# Altium schematic sheet XYAmpRev2b.SchDoc (continued)

## Library data of the parts
C70 C72 = Cap: CAP CER 10PF 50V C0G 0805; Manufacturer: KEMET; Manufacturer Part Number: C0805C100K5GACTU; Dielectric Material: Ceramic; Dissipation Factor: 0.1%; Tolerance: 10%; Capacitance: 10pF; Case Code (Imperial): 0805; Case Code (Metric): 2012; Case/Package: 0805; Component Kind: Standard; Construction: Flat; Contact Plating: Tin,Matte; Depth: 1.25mm; Height: 0.78mm; Lead Free: Lead Free; Lead Pitch: 0.75mm; Length: 2mm; Library Name: Miscellaneous Devices.IntLib; Max Operating Temperature: 125°C; Min Operating Temperature: -55°C; Mount: Surface Mount; Package Quantity: 4000; Packaging: Tape and Reel; Radiation Hardening: No; REACH SVHC: No SVHC; RoHS Compliant: Yes; Series: C; Signal Integrity: Cap; Simulation: CAP; Supplier: Digi-Key; Supplier Part Number: 399-7975-6-ND; Termination: SMD/SMT; Thickness: 0.035inch; Value: 10pF; Voltage Rating: 50V; Voltage Rating (DC): 50V; Width: 0.049inch
C71 C73 = Cap: CAP CER 100PF 50V COG 0805; Manufacturer: KEMET; Manufacturer Part Number: C0805C101J5GACTU; Dielectric Material: Ceramic; Dissipation Factor: 0.1%; Temperature Coefficient: 30ppm/°C; Tolerance: 5%; Capacitance: 100pF; Case Code (Imperial): 0805; Case Code (Metric): 2012; Case/Package: 0805; Component Kind: Standard; Construction: Flat; Contact Material: Tin; Contact Plating: Tin,Matte; Depth: 1.25mm; Height: 0.78mm; Lead Free: Lead Free; Lead Pitch: 0.75mm; Length: 2mm; Library Name: Miscellaneous Devices.IntLib; Max Operating Temperature: 125°C; Min Operating Temperature: -55°C; Mount: Surface Mount,PCB; Number of Pins: 2; Package Quantity: 4000; Packaging: Tape and Reel; Radiation Hardening: No; REACH SVHC: No SVHC; RoHS Compliant: Yes; Series: C; Signal Integrity: Cap; Simulation: CAP; Supplier: Digi-Key; Supplier Part Number: 399-1122-6-ND; Termination: SMD/SMT; Thickness: 0.035inch; Value: 100pF; Voltage: 50V; Voltage Rating: 50V; Voltage Rating (DC): 50V; Width: 1.2mm
C74 = Cap: CAP FILM 0.1UF 20% 63VDC PEN 1210; Manufacturer: KEMET; Manufacturer Part Number: LDEDB3100KA0N00; Capacitance: 100nF; Tolerance: 10%; Voltage: 63V; Voltage Rating (AC): 40V; Case Code (Imperial): 1210; Case Code (Metric): 3225; Case/Package: 1210; Component Kind: Standard; Depth: 2.5mm; Features: High Temperature; Height: 2.1mm; Height - Seated (Max): 0.083inch; Length: 3.3mm; Library Name: Miscellaneous Devices.IntLib; Max Operating Temperature: 125°C; Min Operating Temperature: -55°C; Mount: Surface Mount; Packaging: Cut Tape; REACH SVHC: No SVHC; Signal Integrity: Cap; Simulation: CAP; Supplier: Digi-Key; Supplier Part Number: 399-12863-1-ND; Termination: Solder; Voltage Rating: 63V; Voltage Rating (DC): 63V; Width: 0.098inch
C75 C77 C81 C83 = Cap: CAP CER 10NF 100V X7R 0805; Manufacturer: Samsung; Manufacturer Part Number: CL21B103KCANNNC; Dielectric Material: Ceramic; Dissipation Factor: 2.5%; Tolerance: 10%; Capacitance: 10nF; Case Code (Imperial): 0805; Case Code (Metric): 2012; Case/Package: 0805; Component Kind: Standard; Construction: Flat; Contact Plating: Tin; Depth: 1.25mm; Height: 0.65mm; Length: 2mm; Library Name: Miscellaneous Devices.IntLib; Material: Ceramic; Max Operating Temperature: 125°C; Min Operating Temperature: -55°C; Mount: Surface Mount; Number of Pins: 2; Package Quantity: 1; Packaging: Tape and Reel; Radiation Hardening: No; REACH SVHC: No SVHC; RoHS Compliant: Yes; Series: CL; Signal Integrity: Cap; Simulation: CAP; Supplier: Digi-Key; Supplier Part Number: 1276-1249-6-ND; Termination: SMD/SMT; Thickness: 0.03inch; Value: 10nF; Voltage Rating: 100V; Voltage Rating (DC): 100V; Width: 0.049inch
C76 C78 C80 C82 = Cap: CAP CER 1UF 50V X7R 0805; Manufacturer: Samsung; Manufacturer Part Number: CL21B105KBFNNNE; Dielectric Material: Ceramic; Tolerance: 10%; Capacitance: 1uF; Case Code (Imperial): 0805; Case Code (Metric): 2012; Case/Package: 0805; Component Kind: Standard; Construction: Flat; Depth: 1.25mm; Height: 1.25mm; Length: 2mm; Library Name: Miscellaneous Devices.IntLib; Max Operating Temperature: 125°C; Min Operating Temperature: -55°C; Mount: Surface Mount; Number of Pins: 2; Package Quantity: 1; Packaging: Tape and Reel; Radiation Hardening: No; REACH SVHC: No SVHC; RoHS Compliant: Yes; Series: CL; Signal Integrity: Cap; Simulation: CAP; Supplier: Digi-Key; Supplier Part Number: 1276-1029-6-ND; Termination: SMD/SMT; Thickness: 0.053inch; Value: 1uF; Voltage Rating: 50V; Voltage Rating (DC): 50V; Width: 0.049inch
C79 = Cap Pol1: CAP ALUM 100UF 20% 35V; Manufacturer: Rubycon; Manufacturer Part Number: 35YXJ100M6.3X11; Impedance: 220mR; Lead Diameter: 0.5mm; Leakage Current: 3uA; Life (Hours): 7000Hour; Polarity: Polar; Ripple Current: 400mA; Capacitance: 100uF; Case/Package: Radial; Component Kind: Standard; Diameter: 6.3mm; Height: 11mm; Height - Seated (Max): 0.492inch; Lead Pitch: 2.5mm; Library Name: Miscellaneous Devices.IntLib; Max Operating Temperature: 105°C; Min Operating Temperature: -40°C; Mount: Through Hole; Package Quantity: 3000; Packaging: Bulk; Radiation Hardening: No; Signal Integrity: Cap Pol; Simulation: CAP; Supplier: Digi-Key; Supplier Part Number: 1189-2260-ND; Termination: Radial; Tolerance: 20%; Value: 100uF; Voltage Rating: 35V
C84 = Cap Pol1: CAP ALUM 100uF 20% 25V; Manufacturer: Rubycon; Manufacturer Part Number: 25YXJ100M5X11; Impedance: 400mR; Lead Diameter: 0.5mm; Leakage Current: 3uA; Life (Hours): 5000Hour; Polarity: Polar; Ripple Current: 250mA; Capacitance: 100uF; Case/Package: Radial; Category: Aluminum Electrolytic Capacitors; Component Kind: Standard; ComponentLink1Description: https://datasheet.ciiva.com/11777/0900766b810307a1-11777001.pdf?src-supplier=RSComponents; ComponentLink1URL: https://datasheet.ciiva.com/11777/0900766b810307a1-11777001.pdf?src-supplier=RSComponents; ComponentLink2Description: https://datasheet.ciiva.com/41806/e-yxj-41806041.pdf?src-supplier=Digi-Key; ComponentLink2URL: https://datasheet.ciiva.com/41806/e-yxj-41806041.pdf?src-supplier=Digi-Key; ComponentLink3Description: https://datasheet.ciiva.com/11690/e-yxj-11690904.pdf?src-supplier=Avnet; ComponentLink3URL: https://datasheet.ciiva.com/11690/e-yxj-11690904.pdf?src-supplier=Avnet; Construction: RADIAL; Diameter: 5mm; Height: 11mm; Height - Seated (Max): 0.492inch; Lead Pitch: 2mm; Library Name: Miscellaneous Devices.IntLib; Max Operating Temperature: 105°C; Min Operating Temperature: -40°C; Mount: Through Hole; Package Quantity: 3000; Packaging: Bulk; Pricing 1: 1=0.25000, 10=0.17200, 50=0.10360, 100=0.08740, 500=0.05980, 1000=0.05290, 2500=0.05060, 5000=0.04600 (USD); Radiation Hardening: No; RoHS: Yes; RoHS Compliant: Yes; Series: YXJ; Signal Integrity: Cap Pol; Simulation: CAP; Stock 1: 7789; Supplier: Digi-Key; Supplier Part Number 1: 1189-2237-ND; Termination: Radial; Tolerance: 20%; Value: 100uF; Voltage Rating: 25V
C85 C86 = Cap: CAP CER 100PF 250V COG 0805; Manufacturer: Yageo; Manufacturer Part Number: AC0805JRNPOYBN101; Category: Ceramic Capacitors; Component Kind: Standard; ComponentLink1Description: http://www.yageo.com/NewPortal/_en/search/productDocs.jsp?YageoPartNumber=AC0805JRNPOYBN101&src-supplier=Digi-Key; ComponentLink1URL: http://www.yageo.com/NewPortal/_en/search/productDocs.jsp?YageoPartNumber=AC0805JRNPOYBN101&src-supplier=Digi-Key; Library Name: Miscellaneous Devices.IntLib; Pricing 1: 1=0.22000, 10=0.14800, 50=0.08920, 100=0.07520, 500=0.05148, 1000=0.04554 (USD); RoHS: Yes; RoHS Compliant: Yes; Signal Integrity: Cap; Simulation: CAP; Supplier: Digi-Key; Supplier Part Number: 311-3140-1-ND
C87 = Cap: CAP CER 0.1UF 50V X7R 0805; Manufacturer: KEMET; Manufacturer Part Number: C0805C104M5RACTU; Capacitance: 100nF; Dielectric Material: Ceramic; Dissipation Factor: 2.5%; Tolerance: 20%; Case Code (Imperial): 0805; Case Code (Metric): 2012; Case/Package: 0805; Component Kind: Standard; Construction: Flat; Depth: 1.25mm; Height: 0.9mm; Length: 2mm; Library Name: Miscellaneous Devices.IntLib; Max Operating Temperature: 125°C; Min Operating Temperature: -55°C; Mount: Surface Mount,PCB; Number of Pins: 2; Package Quantity: 1; Packaging: Tape and Reel; Radiation Hardening: No; REACH SVHC: No SVHC; Series: C; Signal Integrity: Cap; Simulation: CAP; Supplier: Digi-Key; Supplier Part Number: 399-1169-2-ND; Termination: SMD/SMT; Thickness: 0.035inch; Value: 0.1uF; Voltage Rating: 50V; Voltage Rating (DC): 50V; Weight: 0.000194oz; Width: 1.2mm
D70 = Diode 1N4148: Diode; 500 mA (Surge); 1.0 V (Max.); 400 mW; 450 degC/W; 4 pF (Max.); 4 nS (Max; Manufacturer: Vishay; Manufacturer Part Number: 1N4148W-E3-18; Average Rectified Current: 150mA; Element Configuration: Single; Forward Voltage: 1.2V; Max Power Dissipation: 350mW; Max Repetitive Reverse Voltage (Vrrm): 100V; Max Reverse Current: 100uA; Max Reverse Leakage Current: 5uA; Max Reverse Voltage (DC): 75V; Max Surge Current: 2A; Recovery Time: 4ns; Category: Rectifier Diodes; Component Kind: Standard; ComponentLink1Description: Manufacturer Link; ComponentLink1URL: http://www.fairchildsemi.com/; ComponentLink2Description: Datasheet; ComponentLink2URL: http://www.fairchildsemi.com/ds/1N%2F1N4148.pdf; ComponentLink3Description: https://datasheet.ciiva.com/29885/1n4148w-29885941.pdf?src-supplier=Avnet; ComponentLink3URL: https://datasheet.ciiva.com/29885/1n4148w-29885941.pdf?src-supplier=Avnet; ComponentLink4Description: https://datasheet.ciiva.com/29593/1n4148w-29593111.pdf?src-supplier=Digi-Key; ComponentLink4URL: https://datasheet.ciiva.com/29593/1n4148w-29593111.pdf?src-supplier=Digi-Key; ComponentLink5Description: https://datasheet.ciiva.com/29593/1n4148w-29593112.pdf?src-supplier=Digi-Key; ComponentLink5URL: https://datasheet.ciiva.com/29593/1n4148w-29593112.pdf?src-supplier=Digi-Key; ComponentLink6Description: https://datasheet.ciiva.com/29593/1n4148w-29593113.pdf?src-supplier=Digi-Key; ComponentLink6URL: https://datasheet.ciiva.com/29593/1n4148w-29593113.pdf?src-supplier=Digi-Key; Contact Plating: Tin; Forward Current: 150mA; Height: 1.25mm; Lead Free: Lead Free; Length: 2.85mm; Library Name: Miscellaneous Devices.IntLib; Max Operating Temperature: 150°C; Min Operating Temperature: -55°C; Mount: Surface Mount; Number of Pins: 2; Package Quantity: 10000; Packaging: Tape and Reel; Peak Non-Repetitive Surge Current: 2A; Power Dissipation: 350mW; Pricing 1: 1=0.25000, 10=0.20100, 100=0.10660, 500=0.07012, 1000=0.04768, 2000=0.04301, 5000=0.03740 (USD); Radiation Hardening: No; Reverse Recovery Time: 4ns; RoHS: No; Signal Integrity: 1N4148; Simulation: 1N4148; Stock 1: 103899; Supplier: Digi-Key; Supplier Part Number: 1N4148W-E3-18CT-ND; Value: 1N4148; Weight: 0.000363oz; Width: 1.7mm
D73 = Diode 1N4002: Diode Switching 400V 1A 2-Pin DO-214AC T/R; Manufacturer: ON Semiconductor / Fairchild; Capacitance: 12pF; Element Configuration: Single; Forward Voltage: 1.1V; Max Forward Surge Current (Ifsm): 30A; Max Surge Current: 40A; Peak Reverse Current: 1uA; Polarity: Standard; Power Dissipation: 1.4W; Recovery Time: 1800ns; Reverse Recovery Time: 1.8us; Average Rectified Current: 1A; Case/Package: SMA; Component Kind: Standard; ComponentLink1Description: Manufacturer Link; ComponentLink1URL: http://www.fairchildsemi.com/; ComponentLink2Description: Datasheet; ComponentLink2URL: http://www.fairchildsemi.com/ds/1N%2F1N4001.pdf; Contact Plating: Tin,Matte; Current Rating: 1A; Forward Current: 1A; Height: 2.2mm; Lead Free: Lead Free; Length: 4.75mm; Library Name: Miscellaneous Devices.IntLib; Manufacturer Part Number: S1G; Max Operating Temperature: 150°C; Max Repetitive Reverse Voltage (Vrrm): 400V; Max Reverse Voltage (DC): 400V; Min Operating Temperature: -55°C; Mount: Surface Mount; Number of Pins: 2; Output Current: 1A; Package Quantity: 1; Packaging: Tape and Reel; Peak Non-Repetitive Surge Current: 30A; Radiation Hardening: No; REACH SVHC: No SVHC; Reverse Voltage: 400V; Signal Integrity: 1N4002; Simulation: 1N4002; Supplier: Digi-Key; Supplier Part Number: S1GFSCT-ND; Value: S1G; Voltage Rating (DC): 400V; Weight: 0.106g; Width: 2.95mm
J70 = 2-Pin: 5.08mm 2pin; Manufacturer: CUI Devices; Manufacturer Part Number: TB004-508-02BE; Category: Terminal Blocks; Component Kind: Standard; ComponentLink1Description: https://datasheet.ciiva.com/709/eng-cd-640456-w1-709680.pdf?src-supplier=Digi-Key; ComponentLink1URL: https://datasheet.ciiva.com/709/eng-cd-640456-w1-709680.pdf?src-supplier=Digi-Key; ComponentLink2Description: https://datasheet.ciiva.com/3118/eng-ds-82056-mta-0608-3118497.pdf?src-supplier=Avnet; ComponentLink2URL: https://datasheet.ciiva.com/3118/eng-ds-82056-mta-0608-3118497.pdf?src-supplier=Avnet; ComponentLink3Description: https://datasheet.ciiva.com/16401/eng-ds-82056-mta-0608-16401289.pdf?src-supplier=Future+Electronics; ComponentLink3URL: https://datasheet.ciiva.com/16401/eng-ds-82056-mta-0608-16401289.pdf?src-supplier=Future+Electronics; ComponentLink4Description: https://datasheet.ciiva.com/25617/0900766b80027008-25617244.pdf?src-supplier=RSComponents; ComponentLink4URL: https://datasheet.ciiva.com/25617/0900766b80027008-25617244.pdf?src-supplier=RSComponents; ComponentLink5Description: https://datasheet.ciiva.com/14156/04384301-14156820.pdf?src-supplier=Distrelec; ComponentLink5URL: https://datasheet.ciiva.com/14156/04384301-14156820.pdf?src-supplier=Distrelec; ComponentLink6Description: https://datasheet.ciiva.com/11746/0900766b80eb7fdd-11746365.pdf?src-supplier=RSComponents; ComponentLink6URL: https://datasheet.ciiva.com/11746/0900766b80eb7fdd-11746365.pdf?src-supplier=RSComponents; ComponentLink7Description: https://datasheet.ciiva.com/7128/1866390-7128742.pdf?src-supplier=Newark; ComponentLink7URL: https://datasheet.ciiva.com/7128/1866390-7128742.pdf?src-supplier=Newark; ComponentLink8Description: https://datasheet.ciiva.com/16505/eng-ds-82056-mta-0608-16505419.pdf?src-supplier=Avnet+Asia; ComponentLink8URL: https://datasheet.ciiva.com/16505/eng-ds-82056-mta-0608-16505419.pdf?src-supplier=Avnet+Asia; ComponentLink9Description: https://datasheet.ciiva.com/16047/1984976-16047357.pdf?src-supplier=Onlinecomponents.com; ComponentLink9URL: https://datasheet.ciiva.com/16047/1984976-16047357.pdf?src-supplier=Onlinecomponents.com; ComponentLink10Description: https://datasheet.ciiva.com/11758/0900766b811d406d-11758596.pdf?src-supplier=RSComponents; ComponentLink10URL: https://datasheet.ciiva.com/11758/0900766b811d406d-11758596.pdf?src-supplier=RSComponents; ComponentLink11Description: https://datasheet.ciiva.com/26840/getdatasheetpartid-743835-26840596.pdf?src-supplier=Verical; ComponentLink11URL: https://datasheet.ciiva.com/26840/getdatasheetpartid-743835-26840596.pdf?src-supplier=Verical; ComponentLink12Description: https://datasheet.ciiva.com/6752/combiconspring-cagepcbterm-blocks-6752927.pdf?src-supplier=Digi-Key; ComponentLink12URL: https://datasheet.ciiva.com/6752/combiconspring-cagepcbterm-blocks-6752927.pdf?src-supplier=Digi-Key; Library Name: MY_CONNECTORS.SchLib; Pricing 1: 1=0.80000, 10=0.49800, 25=0.42600, 50=0.38300, 100=0.37510, 250=0.31920, 500=0.30324, 1000=0.27132, 5000=0.21280 (USD); RoHS: Yes; Stock 1: 216; Supplier: Digi-Key; Supplier Part Number: 102-6179-ND
J71 J72 = 2-Pin: Conn PC Terminal Block 2 POS 3.5mm Solder Thru-Hole 17.5A; Manufacturer: Phoenix Contact; Approvals: UL,EAC; Current: 10A; Gender: Male; Max Supply Voltage: 160V; Number of Circuits: 2; Number of Levels: 1; Value: 1988956; Voltage: 300V; Wire Gauge (Max): 26AWG; Wire Gauge (Min): 16AWG; Category: Terminal Blocks; Color: Green; Component Kind: Standard; ComponentLink1Description: https://datasheet.ciiva.com/709/eng-cd-640456-w1-709680.pdf?src-supplier=Digi-Key; ComponentLink1URL: https://datasheet.ciiva.com/709/eng-cd-640456-w1-709680.pdf?src-supplier=Digi-Key; ComponentLink2Description: https://datasheet.ciiva.com/3118/eng-ds-82056-mta-0608-3118497.pdf?src-supplier=Avnet; ComponentLink2URL: https://datasheet.ciiva.com/3118/eng-ds-82056-mta-0608-3118497.pdf?src-supplier=Avnet; ComponentLink3Description: https://datasheet.ciiva.com/16401/eng-ds-82056-mta-0608-16401289.pdf?src-supplier=Future+Electronics; ComponentLink3URL: https://datasheet.ciiva.com/16401/eng-ds-82056-mta-0608-16401289.pdf?src-supplier=Future+Electronics; ComponentLink4Description: https://datasheet.ciiva.com/25617/0900766b80027008-25617244.pdf?src-supplier=RSComponents; ComponentLink4URL: https://datasheet.ciiva.com/25617/0900766b80027008-25617244.pdf?src-supplier=RSComponents; ComponentLink5Description: https://datasheet.ciiva.com/14156/04384301-14156820.pdf?src-supplier=Distrelec; ComponentLink5URL: https://datasheet.ciiva.com/14156/04384301-14156820.pdf?src-supplier=Distrelec; ComponentLink6Description: https://datasheet.ciiva.com/11746/0900766b80eb7fdd-11746365.pdf?src-supplier=RSComponents; ComponentLink6URL: https://datasheet.ciiva.com/11746/0900766b80eb7fdd-11746365.pdf?src-supplier=RSComponents; ComponentLink7Description: https://datasheet.ciiva.com/7128/1866390-7128742.pdf?src-supplier=Newark; ComponentLink7URL: https://datasheet.ciiva.com/7128/1866390-7128742.pdf?src-supplier=Newark; ComponentLink8Description: https://datasheet.ciiva.com/16505/eng-ds-82056-mta-0608-16505419.pdf?src-supplier=Avnet+Asia; ComponentLink8URL: https://datasheet.ciiva.com/16505/eng-ds-82056-mta-0608-16505419.pdf?src-supplier=Avnet+Asia; ComponentLink9Description: https://datasheet.ciiva.com/18620/1713017-18620870.pdf?src-supplier=Digi-Key; ComponentLink9URL: https://datasheet.ciiva.com/18620/1713017-18620870.pdf?src-supplier=Digi-Key; ComponentLink10Description: https://datasheet.ciiva.com/16047/1989748-16047438.pdf?src-supplier=Onlinecomponents.com; ComponentLink10URL: https://datasheet.ciiva.com/16047/1989748-16047438.pdf?src-supplier=Onlinecomponents.com; ComponentLink11Description: https://datasheet.ciiva.com/11758/0900766b811d404e-11758587.pdf?src-supplier=RSComponents; ComponentLink11URL: https://datasheet.ciiva.com/11758/0900766b811d404e-11758587.pdf?src-supplier=RSComponents; ComponentLink12Description: https://datasheet.ciiva.com/6746/combiconspring-cagepcbterm-blocks-6746175.pdf?src-supplier=Digi-Key; ComponentLink12URL: https://datasheet.ciiva.com/6746/combiconspring-cagepcbterm-blocks-6746175.pdf?src-supplier=Digi-Key; ComponentLink13Description: https://datasheet.ciiva.com/14607/70055059-14607702.pdf?src-supplier=Allied+Electronics; ComponentLink13URL: https://datasheet.ciiva.com/14607/70055059-14607702.pdf?src-supplier=Allied+Electronics; ComponentLink14Description: https://datasheet.ciiva.com/16051/1988956-16051359.pdf?src-supplier=Onlinecomponents.com; ComponentLink14URL: https://datasheet.ciiva.com/16051/1988956-16051359.pdf?src-supplier=Onlinecomponents.com; ComponentLink15Description: https://datasheet.ciiva.com/26340/567541-da-01-de-printklemme-1988956-250-st-26340451.pdf?src-supplier=Conrad; ComponentLink15URL: https://datasheet.ciiva.com/26340/567541-da-01-de-printklemme-1988956-250-st-26340451.pdf?src-supplier=Conrad; ComponentLink16Description: https://datasheet.ciiva.com/824/combiconcompactseries-824224.pdf?src-supplier=Digi-Key; ComponentLink16URL: https://datasheet.ciiva.com/824/combiconcompactseries-824224.pdf?src-supplier=Digi-Key; ComponentLink17Description: https://datasheet.ciiva.com/14609/70055171-14609100.pdf?src-supplier=Allied+Electronics; ComponentLink17URL: https://datasheet.ciiva.com/14609/70055171-14609100.pdf?src-supplier=Allied+Electronics; Contact Material: Tin; Current Rating: 17.5A; Depth: 11.2mm; Flammability Rating: UL94 V-0; Height: 11.2mm; Housing Color: Green; Housing Material: Polyamide; Lead Free: Lead Free; Length: 7mm; Library Name: MY_CONNECTORS.SchLib; Manufacturer Part Number: 1988956; Material: Polyamide; Max Current Rating: 17.5A; Mount: Through Hole; Number of Contacts: 2; Number of Pins: 2; Number of Poles: 2; Number of Positions: 2; Number of Positions Per Level: 2; Number of Rows: 1; Orientation: Straight; Package Quantity: 250; Packaging: Bulk; Pitch: 3.5mm; Pricing 1: 1=0.55000, 10=0.52900, 50=0.50600, 100=0.49220, 250=0.46000, 500=0.43792 (USD); RoHS: Yes; RoHS Compliant: Yes; Series: COMBICON PTA; Stock 1: 7961; Supplier: Digi-Key; Supplier Part Number: 277-1779-ND; Termination: Screw; Voltage Rating: 160V; Weight: 0.034216oz; Width: 13.7mm
J73 J76 = Banana-RED: Conn Banana Plug SKT 1 POS Solder ST Panel Mount 1 Port; Manufacturer: Radiall; Manufacturer Part Number: R921921000; Current Rating: 10A; Gender: Male; Value: Red; Voltage Rating: 250V; Category: RF / Coaxial Connectors; Component Kind: Standard; ComponentLink1Description: https://datasheet.ciiva.com/3111/pi-105-0802-001-3111578.pdf?src-supplier=Avnet; ComponentLink1URL: https://datasheet.ciiva.com/3111/pi-105-0802-001-3111578.pdf?src-supplier=Avnet; ComponentLink2Description: https://datasheet.ciiva.com/1351/187467-1351409.pdf?src-supplier=Element14; ComponentLink2URL: https://datasheet.ciiva.com/1351/187467-1351409.pdf?src-supplier=Element14; ComponentLink3Description: https://datasheet.ciiva.com/22685/1720390-22685221.pdf?src-supplier=Element14; ComponentLink3URL: https://datasheet.ciiva.com/22685/1720390-22685221.pdf?src-supplier=Element14; ComponentLink4Description: https://datasheet.ciiva.com/389/pi-1050802001-389581.pdf?src-supplier=Digi-Key; ComponentLink4URL: https://datasheet.ciiva.com/389/pi-1050802001-389581.pdf?src-supplier=Digi-Key; ComponentLink5Description: https://datasheet.ciiva.com/1350/187467-1350358.pdf?src-supplier=Farnell; ComponentLink5URL: https://datasheet.ciiva.com/1350/187467-1350358.pdf?src-supplier=Farnell; ComponentLink6Description: https://datasheet.ciiva.com/46410/1804694-46410470.pdf?src-supplier=Element14; ComponentLink6URL: https://datasheet.ciiva.com/46410/1804694-46410470.pdf?src-supplier=Element14; Contact Material: Brass; Contact Plating: Nickel; Library Name: MY_CONNECTORS.SchLib; Mount: Panel; Number of Pins: 1; Orientation: Straight; Packaging: Bulk; Pricing 1: 1=1.81000, 10=1.57400, 25=1.50760, 50=1.47400, 100=1.40700, 250=1.27300, 500=1.17250, 1000=1.00500, 2500=0.93800 (USD); REACH SVHC: Unknown; RoHS: Yes; RoHS Compliant: Yes; Stock 1: 810; Supplier: Digi-Key; Supplier Part Number: 2201-R921921000-ND; Termination: Solder; Voltage Rating (DC): 250V
J74 = 2-Pin: 2.54mm 2pin; Manufacturer: TE Connectivity; Manufacturer Part Number: 640456-2; Approvals: CSA; Backwall/Post Interruptions: No (Without); Circuit Application: Signal; Connector System: Wire-to-Board; Connector Type: Connector,Pin,Plug,Header; Contact Current Rating: 5A; Contact Post Length: 3.56mm; Contact Resistance: 6mR; Contact Style: Straight; Gender: Male; Mating Connector Lock: Yes (With); Max Voltage Rating (AC): 250V; Number of Contacts: 2; PCB Mount Retention: Without; PCB Mounting Orientation: Vertical; PCB Thickness: 0.063inch; Plating Thickness: 3.81um; Suppl: Digi-Key; Tail Length: 0.14inch; Value: 640456-2; Category: Headers and Wire Housings; Color: Natural,White,Beige; Component Kind: Standard; ComponentLink1Description: https://datasheet.ciiva.com/709/eng-cd-640456-w1-709680.pdf?src-supplier=Digi-Key; ComponentLink1URL: https://datasheet.ciiva.com/709/eng-cd-640456-w1-709680.pdf?src-supplier=Digi-Key; ComponentLink2Description: https://datasheet.ciiva.com/3118/eng-ds-82056-mta-0608-3118497.pdf?src-supplier=Avnet; ComponentLink2URL: https://datasheet.ciiva.com/3118/eng-ds-82056-mta-0608-3118497.pdf?src-supplier=Avnet; ComponentLink3Description: https://datasheet.ciiva.com/16401/eng-ds-82056-mta-0608-16401289.pdf?src-supplier=Future+Electronics; ComponentLink3URL: https://datasheet.ciiva.com/16401/eng-ds-82056-mta-0608-16401289.pdf?src-supplier=Future+Electronics; ComponentLink4Description: https://datasheet.ciiva.com/25617/0900766b80027008-25617244.pdf?src-supplier=RSComponents; ComponentLink4URL: https://datasheet.ciiva.com/25617/0900766b80027008-25617244.pdf?src-supplier=RSComponents; ComponentLink5Description: https://datasheet.ciiva.com/14156/04384301-14156820.pdf?src-supplier=Distrelec; ComponentLink5URL: https://datasheet.ciiva.com/14156/04384301-14156820.pdf?src-supplier=Distrelec; ComponentLink6Description: https://datasheet.ciiva.com/11746/0900766b80eb7fdd-11746365.pdf?src-supplier=RSComponents; ComponentLink6URL: https://datasheet.ciiva.com/11746/0900766b80eb7fdd-11746365.pdf?src-supplier=RSComponents; ComponentLink7Description: https://datasheet.ciiva.com/7128/1866390-7128742.pdf?src-supplier=Newark; ComponentLink7URL: https://datasheet.ciiva.com/7128/1866390-7128742.pdf?src-supplier=Newark; ComponentLink8Description: https://datasheet.ciiva.com/16505/eng-ds-82056-mta-0608-16505419.pdf?src-supplier=Avnet+Asia; ComponentLink8URL: https://datasheet.ciiva.com/16505/eng-ds-82056-mta-0608-16505419.pdf?src-supplier=Avnet+Asia; ComponentLink9Description: https://datasheet.ciiva.com/18620/1713017-18620870.pdf?src-supplier=Digi-Key; ComponentLink9URL: https://datasheet.ciiva.com/18620/1713017-18620870.pdf?src-supplier=Digi-Key; ComponentLink10Description: https://datasheet.ciiva.com/16047/1989748-16047438.pdf?src-supplier=Onlinecomponents.com; ComponentLink10URL: https://datasheet.ciiva.com/16047/1989748-16047438.pdf?src-supplier=Onlinecomponents.com; ComponentLink11Description: https://datasheet.ciiva.com/11758/0900766b811d404e-11758587.pdf?src-supplier=RSComponents; ComponentLink11URL: https://datasheet.ciiva.com/11758/0900766b811d404e-11758587.pdf?src-supplier=RSComponents; ComponentLink12Description: https://datasheet.ciiva.com/6746/combiconspring-cagepcbterm-blocks-6746175.pdf?src-supplier=Digi-Key; ComponentLink12URL: https://datasheet.ciiva.com/6746/combiconspring-cagepcbterm-blocks-6746175.pdf?src-supplier=Digi-Key; ComponentLink13Description: https://datasheet.ciiva.com/14607/70055059-14607702.pdf?src-supplier=Allied+Electronics; ComponentLink13URL: https://datasheet.ciiva.com/14607/70055059-14607702.pdf?src-supplier=Allied+Electronics; ComponentLink14Description: https://datasheet.ciiva.com/16051/1988956-16051359.pdf?src-supplier=Onlinecomponents.com; ComponentLink14URL: https://datasheet.ciiva.com/16051/1988956-16051359.pdf?src-supplier=Onlinecomponents.com; ComponentLink15Description: https://datasheet.ciiva.com/26340/567541-da-01-de-printklemme-1988956-250-st-26340451.pdf?src-supplier=Conrad; ComponentLink15URL: https://datasheet.ciiva.com/26340/567541-da-01-de-printklemme-1988956-250-st-26340451.pdf?src-supplier=Conrad; ComponentLink16Description: https://datasheet.ciiva.com/824/combiconcompactseries-824224.pdf?src-supplier=Digi-Key; ComponentLink16URL: https://datasheet.ciiva.com/824/combiconcompactseries-824224.pdf?src-supplier=Digi-Key; ComponentLink17Description: https://datasheet.ciiva.com/14609/70055171-14609100.pdf?src-supplier=Allied+Electronics; ComponentLink17URL: https://datasheet.ciiva.com/14609/70055171-14609100.pdf?src-supplier=Allied+Electronics; Contact Gender: Male; Contact Material: Copper Alloy; Contact Mating Length: 7.49mm; Contact Plating: Tin; Contact Retention: No (Without); Contact Shape: Square; Contact Type: Male Pin; Current Rating: 5A; Depth: 5.72mm; ELV: Compliant; Fastening Type: Friction; Flammability Rating: UL94 V-0; Height: 7.87mm; Housing Color: Natural; Housing Material: Polyester; Lead Free: Lead Free; Lead Pitch: 2.54mm; Length: 7.62mm; Library Name: MY_CONNECTORS.SchLib; Mating Alignment: No (Without); Mating Retention: No (Without); Max Operating Temperature: 221°C; Min Operating Temperature: -67°C; Mount: Through Hole; Mounting Ears: No (Without); Number of Poles: 2; Number of Positions: 2; Number of Rows: 1; Orientation: Straight; Package Quantity: 5000; Packaging: Bulk; Panel Mount Retention: No (Without); PCB Mount Alignment: No (Without); Pitch: 2.54mm; Pricing 1: 1=0.13000, 10=0.10400, 100=0.07700, 500=0.06374, 1000=0.05578, 5000=0.04781, 10000=0.04648 (USD); Radiation Hardening: No; REACH SVHC: No SVHC; RoHS: Yes; RoHS Compliant: Yes; Sealable: No (Without); Series: MTA-100; Shrouded: No (Without); Stock 1: 18986; Strain Relief: No (Without); Supplier Part Number: A1921-ND; Termination: Solder; Voltage Rating: 250V; Voltage Rating (AC): 250V; Working Voltage: 250V
J75 J78 = Banana-BLACK: Conn Banana RCP 1 POS Solder Cup ST Cable Mount 1 Port; Manufacturer: Radiall; Manufacturer Part Number: R921920000; Current Rating: 10A; Gender: Male; Max Current Rating: 7A; Number of Ports: 1; Value: Black; Voltage Rating: 250V; Category: RF / Coaxial Connectors; Component Kind: Standard; ComponentLink1Description: https://datasheet.ciiva.com/1378/pi-105-0803-001-1378770.pdf?src-supplier=Newark; ComponentLink1URL: https://datasheet.ciiva.com/1378/pi-105-0803-001-1378770.pdf?src-supplier=Newark; ComponentLink2Description: https://datasheet.ciiva.com/3111/pi-105-0803-001-3111621.pdf?src-supplier=Avnet; ComponentLink2URL: https://datasheet.ciiva.com/3111/pi-105-0803-001-3111621.pdf?src-supplier=Avnet; ComponentLink3Description: https://datasheet.ciiva.com/1351/187468-1351410.pdf?src-supplier=Element14; ComponentLink3URL: https://datasheet.ciiva.com/1351/187468-1351410.pdf?src-supplier=Element14; ComponentLink4Description: https://datasheet.ciiva.com/389/pi-1050803001-389580.pdf?src-supplier=Digi-Key; ComponentLink4URL: https://datasheet.ciiva.com/389/pi-1050803001-389580.pdf?src-supplier=Digi-Key; ComponentLink5Description: https://datasheet.ciiva.com/18405/70090219-18405895.pdf?src-supplier=Allied+Electronics; ComponentLink5URL: https://datasheet.ciiva.com/18405/70090219-18405895.pdf?src-supplier=Allied+Electronics; ComponentLink6Description: https://datasheet.ciiva.com/30201/pi-105-0803-001-30201541.pdf?src-supplier=Onlinecomponents.com; ComponentLink6URL: https://datasheet.ciiva.com/30201/pi-105-0803-001-30201541.pdf?src-supplier=Onlinecomponents.com; ComponentLink7Description: https://datasheet.ciiva.com/1350/187468-1350359.pdf?src-supplier=Farnell; ComponentLink7URL: https://datasheet.ciiva.com/1350/187468-1350359.pdf?src-supplier=Farnell; ComponentLink8Description: https://datasheet.ciiva.com/22685/pi-105-0803-001-22685222.pdf?src-supplier=Element14; ComponentLink8URL: https://datasheet.ciiva.com/22685/pi-105-0803-001-22685222.pdf?src-supplier=Element14; ComponentLink9Description: https://datasheet.ciiva.com/26839/getdatasheetpartid-752814-26839702.pdf?src-supplier=Verical; ComponentLink9URL: https://datasheet.ciiva.com/26839/getdatasheetpartid-752814-26839702.pdf?src-supplier=Verical; ComponentLink10Description: https://datasheet.ciiva.com/46410/1804694-46410469.pdf?src-supplier=Element14; ComponentLink10URL: https://datasheet.ciiva.com/46410/1804694-46410469.pdf?src-supplier=Element14; Contact Material: Brass; Contact Plating: Tin,Nickel; Housing Color: Black; Housing Material: Thermoplastic; Length: 19mm; Library Name: MY_CONNECTORS.SchLib; Max Operating Temperature: 100°C; Min Operating Temperature: -40°C; Orientation: Straight; Packaging: Bulk; REACH SVHC: Unknown; RoHS: Yes; RoHS Compliant: Yes; Supplier: Digi-Key; Supplier Part Number: 2201-R921920000-ND; Termination: Solder; Voltage Rating (DC): 250V
J77 J79 = Banana-BLUE: Conn Banana Plug SKT 1 POS Solder ST Panel Mount 1 Port; Manufacturer: Radiall; Manufacturer Part Number: R921921000; Current Rating: 10A; Gender: Male; Value: Blue; Voltage Rating: 250V; Category: RF / Coaxial Connectors; Component Kind: Standard; ComponentLink1Description: https://datasheet.ciiva.com/1378/1768749-1378773.pdf?src-supplier=Newark; ComponentLink1URL: https://datasheet.ciiva.com/1378/1768749-1378773.pdf?src-supplier=Newark; ComponentLink2Description: https://datasheet.ciiva.com/3111/dr-1050810001-3111764.pdf?src-supplier=Avnet; ComponentLink2URL: https://datasheet.ciiva.com/3111/dr-1050810001-3111764.pdf?src-supplier=Avnet; ComponentLink3Description: https://datasheet.ciiva.com/30229/dr-1050810001-30229130.pdf?src-supplier=Onlinecomponents.com; ComponentLink3URL: https://datasheet.ciiva.com/30229/dr-1050810001-30229130.pdf?src-supplier=Onlinecomponents.com; ComponentLink4Description: https://datasheet.ciiva.com/1351/187471-1351413.pdf?src-supplier=Element14; ComponentLink4URL: https://datasheet.ciiva.com/1351/187471-1351413.pdf?src-supplier=Element14; ComponentLink5Description: https://datasheet.ciiva.com/389/pi-1050801001-389657.pdf?src-supplier=Digi-Key; ComponentLink5URL: https://datasheet.ciiva.com/389/pi-1050801001-389657.pdf?src-supplier=Digi-Key; ComponentLink6Description: https://datasheet.ciiva.com/16878/70090222-16878774.pdf?src-supplier=Allied+Electronics; ComponentLink6URL: https://datasheet.ciiva.com/16878/70090222-16878774.pdf?src-supplier=Allied+Electronics; ComponentLink7Description: https://datasheet.ciiva.com/46410/1804694-46410470.pdf?src-supplier=Element14; ComponentLink7URL: https://datasheet.ciiva.com/46410/1804694-46410470.pdf?src-supplier=Element14; Contact Material: Brass; Contact Plating: Nickel; Library Name: MY_CONNECTORS.SchLib; Mount: Panel; Number of Pins: 1; Orientation: Straight; Packaging: Bulk; Pricing 1: 1=1.81000, 10=1.57400, 25=1.50760, 50=1.47400, 100=1.40700, 250=1.27300, 500=1.17250, 1000=1.00500, 2500=0.93800 (USD); REACH SVHC: Unknown; RoHS: Yes; RoHS Compliant: Yes; Stock 1: 810; Supplier: Digi-Key; Supplier Part Number: 2201-R921921000-ND; Termination: Solder; Voltage Rating (DC): 250V
L70 L71 = Inductor: Fixed Ind 4.7UH 1.9A 108MOHM SMD; Manufacturer: Cyntec; Manufacturer Part Number: SDET32251E-4R7MS; Category: Fixed Inductors; Component Kind: Standard; Library Name: Miscellaneous Devices.IntLib; Pricing 1: 1=0.30000, 10=0.26800, 25=0.23440, 50=0.20100, 100=0.18420, 250=0.16748, 500=0.15742, 1000=0.12058 (USD); RoHS: Yes; RoHS Compliant: Yes; Signal Integrity: Inductor; Simulation: INDUCTOR; Stock 1: 21974; Supplier: Digi-Key; Supplier Part Number: 2037-SDET32251E-4R7MSCT-ND
P70 P71 = Header 5: TEST SOCKET 5 PIN; Manufacturer: UNK; Manufacturer Part Number: TO-247-5; Value: TO-247-5; Component Kind: Standard; Library Name: Miscellaneous Connectors.IntLib; Signal Integrity: Connector; Supplier: AliExpress; Supplier Part Number: 1
Pot70 = POT-Single: TRIMMER 1K OHM 0.5W TH; Manufacturer: Nidec Copal; Manufacturer Part Number: CT6EP102; Max Power Dissipation: 500mW; Number of Turns: 1; Resistance: 1kR; Temperature Coefficient: 100ppm/°C; Tolerance: 10%; Color: Blue; Component Kind: Standard; Contact Plating: Tin; Lead Free: Lead Free; Length: 0.228inch; Max Operating Temperature: 120°C; Min Operating Temperature: -55°C; Mount: Through Hole; Packaging: Bulk; Resistor Type: Cermet; Series: CT6; Supplier: Digi-Key; Supplier Part Number: CT6EP102-ND; Termination: PC Pins; Weight: 510mg; Library Name: MY_POTS.SchLib
Pot71 = POT-Single: Res Cermet Trimmer 10K Ohm 10% 1/2W 1(Elec)/1(Mech)Turn 2.6mm (7 X 7 X 8.2mm) Pin Thru-Hole Bulk; Manufacturer: Nidec Copal; Manufacturer Part Number: CT6EP103; Max Power Dissipation: 500mW; Number of Turns: 1; Resistance: 10kR; Temperature Coefficient: 100ppm/°C; Category: Variable Resistors and Potentiometers; Color: Blue; Component Kind: Standard; ComponentLink1Description: https://datasheet.ciiva.com/2156/ct-6-2156210.pdf?src-supplier=Digi-Key; ComponentLink1URL: https://datasheet.ciiva.com/2156/ct-6-2156210.pdf?src-supplier=Digi-Key; Contact Plating: Tin; Lead Free: Lead Free; Length: 0.228inch; Max Operating Temperature: 120°C; Min Operating Temperature: -55°C; Mount: Through Hole; Package Quantity: 50; Packaging: Bulk; Pricing 1: 1=0.87000, 10=0.77100, 25=0.69400, 50=0.66840, 100=0.64270, 250=0.60412, 500=0.51414, 1000=0.47557, 5000=0.39074 (USD); Resistor Type: Cermet; RoHS: Yes; Series: CT6; Stock 1: 762; Supplier: Digi-Key; Supplier Part Number: CT6EX103-ND; Termination: PC Pin; Tolerance: 10%; Weight: 510mg; Library Name: MY_POTS.SchLib
R70 = Res3: RES 100K OHM 1% 1/4W 1206; Manufacturer: Stackpole Electronics; Manufacturer Part Number: RMCF1206FT100K; Max Power Dissipation: 250mW; Resistance: 100kR; Temperature Coefficient: 100ppm/°C; Case Code (Imperial): 1206; Case Code (Metric): 3216; Case/Package: 1206; Component Kind: Standard; Construction: Rectangular; Contact Plating: Tin,Matte; Depth: 1.6mm; Features: Automotive AEC-Q200; Height: 0.028inch; Lead Free: Lead Free; Length: 0.126inch; Library Name: Miscellaneous Devices.IntLib; Max Operating Temperature: 155°C; Military Standard: Not; Min Operating Temperature: -55°C; Mount: Surface Mount; Number of Terminations: 2; Packaging: Tape and Reel; Power Rating: 250mW; Radiation Hardening: No; Resistor Type: Thick Film; RoHS Compliant: Yes; Series: RMCF; Signal Integrity: Res; Simulation: RESISTOR; Size Code: 1206; Supplier: Digi-Key; Supplier Part Number: RMCF1206FT100KCT-ND; Tolerance: 1%; Value: 100K; Width: 0.063inch
R71 R74 R76-R79 = Res3: RES 10K OHM 1% 1/4W 0805; Manufacturer: KOA Speer; Manufacturer Part Number: RK73H2ATTD1002F; Max Power Dissipation: 250mW; Power Rating: 125mW; Resistance: 10kR; Temperature Coefficient: 100ppm/°C; Voltage Rating: 150V; Case Code (Imperial): 0805; Case Code (Metric): 2012; Case/Package: 0805; Component Kind: Standard; Composition: Thick Film; Construction: Rectangular; Contact Plating: Matte,Tin; Depth: 1.25mm; Features: Automotive AEC-Q200; Height: 0.5mm; Lead Free: Lead Free; Length: 2mm; Library Name: Miscellaneous Devices.IntLib; Max Operating Temperature: 155°C; Military Standard: Not; Min Operating Temperature: -55°C; Mount: Surface Mount; Number of Pins: 2; Number of Terminations: 2; Package Quantity: 5000; Packaging: Tape and Reel; Radiation Hardening: No; REACH SVHC: Unknown; Resistance Tolerance: 1%; Resistor Type: Thick Film; Series: RK73H; Signal Integrity: Res; Simulation: RESISTOR; Supplier: Digi-Key; Termination: SMD/SMT; Tolerance: 1%; Value: 10K; Voltage Rating (DC): 150V; Width: 1.25mm
  R71 R74 R77-R79: Supplier Part Number: 2019-RK73H2ATTD1002FCT-ND
  R76: Supplier Part Number: 2019-RK73H2ATTD1002FDKR-ND
R72 R73 R89 = Res3: RES 100K OHM 1% 1/4W 0805; Manufacturer: KOA Speer; Manufacturer Part Number: RK73H2ATTD1003F; Power Rating: 125mW; Resistance: 100kR; Temperature Coefficient: 100ppm/°C; Voltage Rating: 150V; Case Code (Imperial): 0805; Case Code (Metric): 2012; Case/Package: 0805; Component Kind: Standard; Construction: Rectangular; Depth: 1.25mm; Height: 0.5mm; Lead Free: Lead Free; Length: 2mm; Library Name: Miscellaneous Devices.IntLib; Max Operating Temperature: 155°C; Military Standard: Not; Min Operating Temperature: -55°C; Number of Pins: 2; Package Quantity: 5000; Packaging: Tape and Reel; REACH SVHC: Unknown; Resistance Tolerance: 1%; Resistor Type: Thick Film; RoHS Compliant: Yes; Series: RK73H; Signal Integrity: Res; Simulation: RESISTOR; Supplier: Digi-Key; Supplier Part Number: 2019-RK73H2ATTD1003FCT-ND; Termination: SMD/SMT; Tolerance: 1%; Value: 100K; Voltage Rating (DC): 150V; Width: 1.25mm
R75 = Res3: RES 1K OHM 1% 1/4W 0805; Manufacturer: KOA Speer; Manufacturer Part Number: RK73H2ATTD1001F; Power Rating: 125mW; Resistance: 1kR; Temperature Coefficient: 100ppm/°C; Voltage Rating: 150V; Case Code (Imperial): 0805; Case Code (Metric): 2012; Case/Package: 0805; Category: Chip SMD Resistors; Component Kind: Standard; ComponentLink1Description: https://datasheet.ciiva.com/5557/rk73h-5557241.pdf?src-supplier=Mouser; ComponentLink1URL: https://datasheet.ciiva.com/5557/rk73h-5557241.pdf?src-supplier=Mouser; ComponentLink2Description: https://datasheet.ciiva.com/7706/549017-7706107.pdf?src-supplier=Element14; ComponentLink2URL: https://datasheet.ciiva.com/7706/549017-7706107.pdf?src-supplier=Element14; Construction: Rectangular; Depth: 1.25mm; Height: 0.5mm; Lead Free: Lead Free; Length: 2mm; Library Name: Miscellaneous Devices.IntLib; Max Operating Temperature: 155°C; Military Standard: Not; Min Operating Temperature: -55°C; Number of Pins: 2; Package Quantity: 5000; Packaging: Tape and Reel; Pricing 1: 1=0.10000, 10=0.04200, 100=0.01710, 1000=0.00768, 2500=0.00666 (USD); REACH SVHC: Unknown; Resistance Tolerance: 1%; Resistor Type: Thick Film; RoHS: Yes; RoHS Compliant: Yes; Series: RK73H; Signal Integrity: Res; Simulation: RESISTOR; Supplier: Digi-Key; Supplier Part Number: 2019-RK73H2ATTD1001FCT-ND; Termination: SMD/SMT; Tolerance: 1%; Value: 1K; Voltage Rating (DC): 150V; Width: 1.25mm
R80 R81 R86 R90 = Res3: RES 4.02 ohm 1% 2W 2512; Manufacturer: Stackpole Electronics; Manufacturer Part Number: RMCP2512FT4R02; Category: Resistors; Component Kind: Standard; Library Name: Miscellaneous Devices.IntLib; Pricing 1: 1=0.72000, 10=0.60400, 100=0.40540, 1000=0.23719 (USD); Resistance: 4r02; RoHS: Yes; RoHS Compliant: Yes; Signal Integrity: Res; Simulation: RESISTOR; Supplier: Digi-Key; Supplier 1: Digi-Key; Supplier Part Number: 738-RMCP2512FT4R02CT-ND
R82 R83 = Res3: RES 100 OHM 1% 1/4W 0805; Manufacturer: KOA Speer; Manufacturer Part Number: RK73H2ATTD1000F; Max Power Dissipation: 250mW; Power Rating: 125mW; Resistance: 100R; Temperature Coefficient: 100ppm/°C; Voltage Rating: 150V; Case Code (Imperial): 0805; Case Code (Metric): 2012; Case/Package: 0805; Component Kind: Standard; Composition: Thick Film; Construction: Rectangular; Contact Plating: Matte,Tin; Depth: 1.25mm; Features: Automotive AEC-Q200; Height: 0.5mm; Lead Free: Lead Free; Length: 2mm; Library Name: Miscellaneous Devices.IntLib; Max Operating Temperature: 155°C; Military Standard: Not; Min Operating Temperature: -55°C; Mount: Surface Mount; Number of Pins: 2; Number of Terminations: 2; Package Quantity: 5000; Packaging: Tape and Reel; Radiation Hardening: No; REACH SVHC: Unknown; Resistance Tolerance: 1%; Resistor Type: Thick Film; RoHS Compliant: Yes; Series: RK73H; Signal Integrity: Res; Simulation: RESISTOR; Supplier: Digi-Key; Supplier Part Number: 2019-RK73H2ATTD1000FDKR-ND; Technology: THICK FILM; Termination: SMD/SMT; Tolerance: 1%; Value: 100; Voltage Rating (DC): 150V; Width: 1.25mm
R84 = Res3: RES 20K OHM 1% 1/4W 0805; Manufacturer: KOA Speer; Manufacturer Part Number: RK73H2ATTD2002F; Max Power Dissipation: 250mW; Power Rating: 125mW; Resistance: 20kR; Temperature Coefficient: 100ppm/°C; Voltage Rating: 150V; Case Code (Imperial): 0805; Case Code (Metric): 2012; Case/Package: 0805; Component Kind: Standard; Composition: Thick Film; Construction: Rectangular; Contact Plating: Matte,Tin; Depth: 1.25mm; Features: Automotive AEC-Q200; Height: 0.5mm; Lead Free: Lead Free; Length: 2mm; Library Name: Miscellaneous Devices.IntLib; Max Operating Temperature: 155°C; Military Standard: Not; Min Operating Temperature: -55°C; Mount: Surface Mount; Number of Pins: 2; Number of Terminations: 2; Package Quantity: 5000; Packaging: Tape and Reel; Radiation Hardening: No; REACH SVHC: Unknown; Resistance Tolerance: 1%; Resistor Type: Thick Film; Series: RK73H; Signal Integrity: Res; Simulation: RESISTOR; Supplier: Digi-Key; Supplier Part Number: 2019-RK73H2ATTD2002FCT-ND; Termination: SMD/SMT; Tolerance: 1%; Voltage Rating (DC): 150V; Width: 1.25mm
R85 = Res3: RES 1K OHM 1% 1/4W 0805; Manufacturer: KOA Speer; Manufacturer Part Number: RK73H2ATTD1001F; Max Power Dissipation: 250mW; Power Rating: 125mW; Resistance: 1kR; Temperature Coefficient: 100ppm/°C; Voltage Rating: 150V; Case Code (Imperial): 0805; Case Code (Metric): 2012; Case/Package: 0805; Component Kind: Standard; Composition: Thick Film; Construction: Rectangular; Contact Plating: Matte,Tin; Depth: 1.25mm; Features: Automotive AEC-Q200; Height: 0.5mm; Lead Free: Lead Free; Length: 2mm; Library Name: Miscellaneous Devices.IntLib; Max Operating Temperature: 155°C; Military Standard: Not; Min Operating Temperature: -55°C; Mount: Surface Mount; Number of Pins: 2; Number of Terminations: 2; Package Quantity: 5000; Packaging: Tape and Reel; Radiation Hardening: No; REACH SVHC: Unknown; Resistance Tolerance: 1%; Resistor Type: Thick Film; RoHS Compliant: Yes; Series: RK73H; Signal Integrity: Res; Simulation: RESISTOR; Supplier: Digi-Key; Supplier Part Number: 2019-RK73H2ATTD1001FDKR-ND; Termination: SMD/SMT; Tolerance: 1%; Value: 1K; Voltage Rating (DC): 150V; Width: 1.25mm
R87 = Res3: RES 4.99K OHM 1% 1/4W 0805; Manufacturer: KOA Speer; Manufacturer Part Number: RK73H2ATTD4991F; Power Rating: 125mW; Resistance: 4.99kR; Temperature Coefficient: 100ppm/°C; Voltage Rating: 150V; Case Code (Imperial): 0805; Case Code (Metric): 2012; Case/Package: 0805; Category: Chip SMD Resistors; Component Kind: Standard; ComponentLink1Description: http://www.jameco.com/Jameco/Products/ProdDS/1890543.pdf?src-supplier=Jameco; ComponentLink1URL: http://www.jameco.com/Jameco/Products/ProdDS/1890543.pdf?src-supplier=Jameco; ComponentLink2Description: http://components.arrow.com/part/dataview/39727342S7171825N5146?src-supplier=Arrow+Electronics; ComponentLink2URL: http://components.arrow.com/part/dataview/39727342S7171825N5146?src-supplier=Arrow+Electronics; ComponentLink3Description: https://www.verical.com/server-webapp/html/getDatasheet?partID=72454&src-supplier=Verical; ComponentLink3URL: https://www.verical.com/server-webapp/html/getDatasheet?partID=72454&src-supplier=Verical; ComponentLink4Description: http://www.koaspeer.com/catimages/Products/RK73H/RK73H.pdf?src-supplier=Mouser; ComponentLink4URL: http://www.koaspeer.com/catimages/Products/RK73H/RK73H.pdf?src-supplier=Mouser; ComponentLink5Description: http://www.farnell.com/datasheets/549017.pdf?src-supplier=Element14; ComponentLink5URL: http://www.farnell.com/datasheets/549017.pdf?src-supplier=Element14; Construction: Rectangular; Depth: 1.25mm; Height: 0.5mm; Lead Free: Lead Free; Length: 2mm; Library Name: Miscellaneous Devices.IntLib; Max Operating Temperature: 155°C; Military Standard: Not; Min Operating Temperature: -55°C; Mount: Surface Mount; Number of Pins: 2; Package Quantity: 5000; Packaging: Tape and Reel; Pricing 1: 1=0.10000, 10=0.04200, 100=0.01710, 1000=0.00768, 2500=0.00666 (USD); Resistance Tolerance: 1%; Resistor Type: Thick Film; RoHS: Yes; RoHS Compliant: Yes; Series: RK73H; Signal Integrity: Res; Simulation: RESISTOR; Supplier: Digi-Key; Supplier Part Number: 2019-RK73H2ATTD4991FCT-ND; Technology: THICK FILM; Termination: SMD/SMT; Tolerance: 1%; Value: 4.99K; Voltage Rating (DC): 150V; Width: 1.25mm
R88 = Res3: RES 1M OHM 1% 1/8W 0805; Manufacturer: KOA Speer; Manufacturer Part Number: RK73H2ATTD1004F; Power Rating: 125mW; Resistance: 1MR; Temperature Coefficient: 100ppm/°C; Voltage Rating: 150V; Case Code (Imperial): 0805; Case Code (Metric): 2012; Case/Package: 0805; Category: Chip SMD Resistors; Component Kind: Standard; ComponentLink1Description: https://datasheet.ciiva.com/7763/549017-7763157.pdf?src-supplier=Element14; ComponentLink1URL: https://datasheet.ciiva.com/7763/549017-7763157.pdf?src-supplier=Element14; ComponentLink2Description: https://datasheet.ciiva.com/5554/rk73h-5554472.pdf?src-supplier=Mouser; ComponentLink2URL: https://datasheet.ciiva.com/5554/rk73h-5554472.pdf?src-supplier=Mouser; Construction: Rectangular; Depth: 1.25mm; Height: 0.5mm; Lead Free: Lead Free; Length: 2mm; Library Name: Miscellaneous Devices.IntLib; Max Operating Temperature: 155°C; Military Standard: Not; Min Operating Temperature: -55°C; Number of Pins: 2; Package Quantity: 5000; Packaging: Tape and Reel; Pricing 1: 1=0.10000, 10=0.04200, 100=0.01710, 1000=0.00768, 2500=0.00666 (USD); REACH SVHC: Unknown; Resistance Tolerance: 1%; Resistor Type: Thick Film; RoHS: Yes; RoHS Compliant: Yes; Series: RK73H; Signal Integrity: Res; Simulation: RESISTOR; Supplier: Digi-Key; Supplier Part Number: 2019-RK73H2ATTD1004FCT-ND; Termination: SMD/SMT; Tolerance: 1%; Voltage Rating (DC): 150V; Width: 1.25mm
S70 = SPST: Switch Toggle ON None ON SPDT Round Lever PC Pins 5A 250VAC 28VDC PC Mount with Threads; Manufacturer: E-Switch; Manufacturer Part Number: 100SP1T1B1M2QEH; Actuator Length: 10.41mm; Actuator Material: Brass,Chrome; Actuator Type: Standard Round; Electrical Life: 40000Cycles; Illumination Type: Non-Illuminated,Illuminated; Max Voltage Rating (AC): 250V; Max Voltage Rating (DC): 28V; Switch Function: On-On; Value: EG2352; Voltage Rating (AC): 120V; Category: Toggle Switches; Component Kind: Standard; ComponentLink1Description: https://datasheet.ciiva.com/2904/st113013b-2904808.pdf?src-supplier=Digi-Key; ComponentLink1URL: https://datasheet.ciiva.com/2904/st113013b-2904808.pdf?src-supplier=Digi-Key; ComponentLink2Description: https://datasheet.ciiva.com/31844/100-31844510.pdf?src-supplier=Onlinecomponents.com; ComponentLink2URL: https://datasheet.ciiva.com/31844/100-31844510.pdf?src-supplier=Onlinecomponents.com; ComponentLink3Description: https://datasheet.ciiva.com/16353/100-16353365.pdf?src-supplier=Future+Electronics; ComponentLink3URL: https://datasheet.ciiva.com/16353/100-16353365.pdf?src-supplier=Future+Electronics; ComponentLink4Description: https://datasheet.ciiva.com/2905/3003541-2905496.pdf?src-supplier=Digi-Key; ComponentLink4URL: https://datasheet.ciiva.com/2905/3003541-2905496.pdf?src-supplier=Digi-Key; ComponentLink5Description: https://datasheet.ciiva.com/16154/st110004-16154565.pdf?src-supplier=Onlinecomponents.com; ComponentLink5URL: https://datasheet.ciiva.com/16154/st110004-16154565.pdf?src-supplier=Onlinecomponents.com; ComponentLink6Description: https://datasheet.ciiva.com/16154/st110001-16154563.pdf?src-supplier=Onlinecomponents.com; ComponentLink6URL: https://datasheet.ciiva.com/16154/st110001-16154563.pdf?src-supplier=Onlinecomponents.com; ComponentLink7Description: https://datasheet.ciiva.com/16323/100-16323306.pdf?src-supplier=Future+Electronics; ComponentLink7URL: https://datasheet.ciiva.com/16323/100-16323306.pdf?src-supplier=Future+Electronics; ComponentLink8Description: https://datasheet.ciiva.com/2904/100-2904800.pdf?src-supplier=Digi-Key; ComponentLink8URL: https://datasheet.ciiva.com/2904/100-2904800.pdf?src-supplier=Digi-Key; Contact Material: Silver; Contact Plating: Silver; Current Rating: 5A; Lead Free: Lead Free; Library Name: MY_SWITCH.SchLib; Max Operating Temperature: 85°C; Min Operating Temperature: -30°C; Mount: Panel; Orientation: Straight; Package Quantity: 1; Packaging: Bulk; Pricing 1: 1=2.64000, 10=2.36600, 25=2.23640, 50=2.13940, 100=2.03130, 250=1.94484, 500=1.80438, 1000=1.71795 (USD); RoHS: Yes; RoHS Compliant: Yes; Series: 100; Supplier: Digi-Key; Supplier Part Number: EG2352-ND; Termination: PC Pin; Throw Configuration: SPDT; Voltage Rating (DC): 28V
S71 = 4PDT-Slide: Switch Toggle ON OFF ON DPDT Round Lever PC Pins 5A 250VAC 28VDC PC Mount with Threads; Manufacturer: E-Switch; Manufacturer Part Number: 100DP3T1B1M2QEH; Actuator Length: 10.41mm; Actuator Material: Brass,Chrome; Actuator Type: Standard Round; Electrical Life: 40000Cycles; Illumination Type: Illuminated,Non-Illuminated; Switch Function: On-Off-On; Value: EG2414; Voltage Rating (AC): 120V; Voltage Rating (DC): 28V; Category: Toggle Switches; Component Kind: Standard; ComponentLink1Description: https://datasheet.ciiva.com/2904/sp1004-series-jpg-2904855.pdf?src-supplier=Digi-Key; ComponentLink1URL: https://datasheet.ciiva.com/2904/sp1004-series-jpg-2904855.pdf?src-supplier=Digi-Key; Contact Material: Copper,Silver; Contact Plating: Silver; Current Rating: 5A; Lead Free: Lead Free; Library Name: MY_SWITCH.SchLib; Max Operating Temperature: 85°C; Min Operating Temperature: -30°C; Mount: Panel; Orientation: Vertical; Package Quantity: 1; Packaging: Bulk; Pricing 1: 1=3.95000, 10=3.52800, 25=3.34240, 50=3.22440, 100=3.05560, 250=2.92052, 500=2.68420, 1000=2.56602 (USD); RoHS: Yes; RoHS Compliant: Yes; Series: 100; Stock 1: 1296; Supplier: Digi-Key; Supplier Part Number: EG2414-ND; Termination: PC Pin; Throw Configuration: DPDT
S72 = 3PDT: SWITCH TOGGLE 3PDT 5A 120V; Manufacturer: E-Switch; Manufacturer Part Number: 1003P1T1B1M2QEH; Actuator Length: 10.41mm; Actuator Material: Brass,Chrome; Actuator Type: Standard Round; Electrical Life: 40000Cycles; Illumination Type: Non-Illuminated,Illuminated; Switch Function: On-On; Value: EG2425; Voltage Rating (DC): 28V; Component Kind: Standard; Contact Material: Copper,Silver; Contact Plating: Silver; Current Rating: 5A; Lead Free: Lead Free; Length: 12.7mm; Library Name: MY_SWITCH.SchLib; Max Operating Temperature: 85°C; Min Operating Temperature: -30°C; Mount: Panel; Orientation: Vertical; Package Quantity: 1; Packaging: Bulk; Series: 100; Supplier: Digi-Key; Supplier Part Number: EG2425-ND; Termination: PC Pin; Throw Configuration: 3PDT; Voltage Rating (AC): 120V; Width: 16.5mm
TP70 = TP-Round-Black: TP Thru-Hole; Manufacturer: UW; Manufacturer Part Number: Gold; Component Kind: Standard; Library Name: MY_TP.SchLib; Supplier: UW; Supplier Part Number: Gold; Value: TP Thru-Hole
U70 = Dual OP Amp separte supplies: 40-V, dual 1MHz, rail-to-rail input/output, low-offset-voltage, low-power op amp 8-SOIC -40 to 125; Manufacturer: Texas Instruments; Manufacturer Part Number: OPA2990IDR; Category: Amplifiers - Op Amps, Buffer, Instrumentation; Component Kind: Standard; ComponentLink1Description: http://www.ti.com/general/docs/suppproductinfo.tsp?distId=10&amp;gotoUrl=http://www.ti.com/lit/gpn/OPA2990&src-supplier=Digi-Key; ComponentLink1URL: http://www.ti.com/general/docs/suppproductinfo.tsp?distId=10&amp;gotoUrl=http://www.ti.com/lit/gpn/OPA2990&src-supplier=Digi-Key; Library Name: My_OpAmps.SchLib; Pricing 1: 1=1.59000, 10=1.42700, 25=1.34640, 100=1.14700, 250=1.07696, 500=0.94234, 1000=0.88900 (USD); RoHS: Yes; Stock 1: 3095; Supplier: Digi-Key; Supplier Part Number: 296-53506-1-ND; Value: OPA2990
U71 = Single OP Amp separate supply.: HIGH PRECISION, LOW NOISE, LOW POWER OP-AMP; Manufacturer: Texas Instruments; Manufacturer Part Number: OPA207ID; Gain Bandwidth Product: 1.3MHz; Input Bias Current: 200pA; Input Offset Voltage (Vos): 15uV; Number of Channels: 1; Operating Supply Current: 350uA; Slew Rate: 2.7V/µs; Case/Package: SOIC; Component Kind: Standard; Library Name: My_OpAmps.SchLib; Max Operating Temperature: 125°C; Min Operating Temperature: -40°C; Mount: Surface Mount; Number of Circuits: 1; Packaging: Rail/Tube; RoHS Compliant: Yes; Supplier: Digi-Key; Supplier Part Number: 296-47934-ND; Value: OPA207A
